# Altium schematic sheet USBgpib-v3-USBTMC.SchDoc
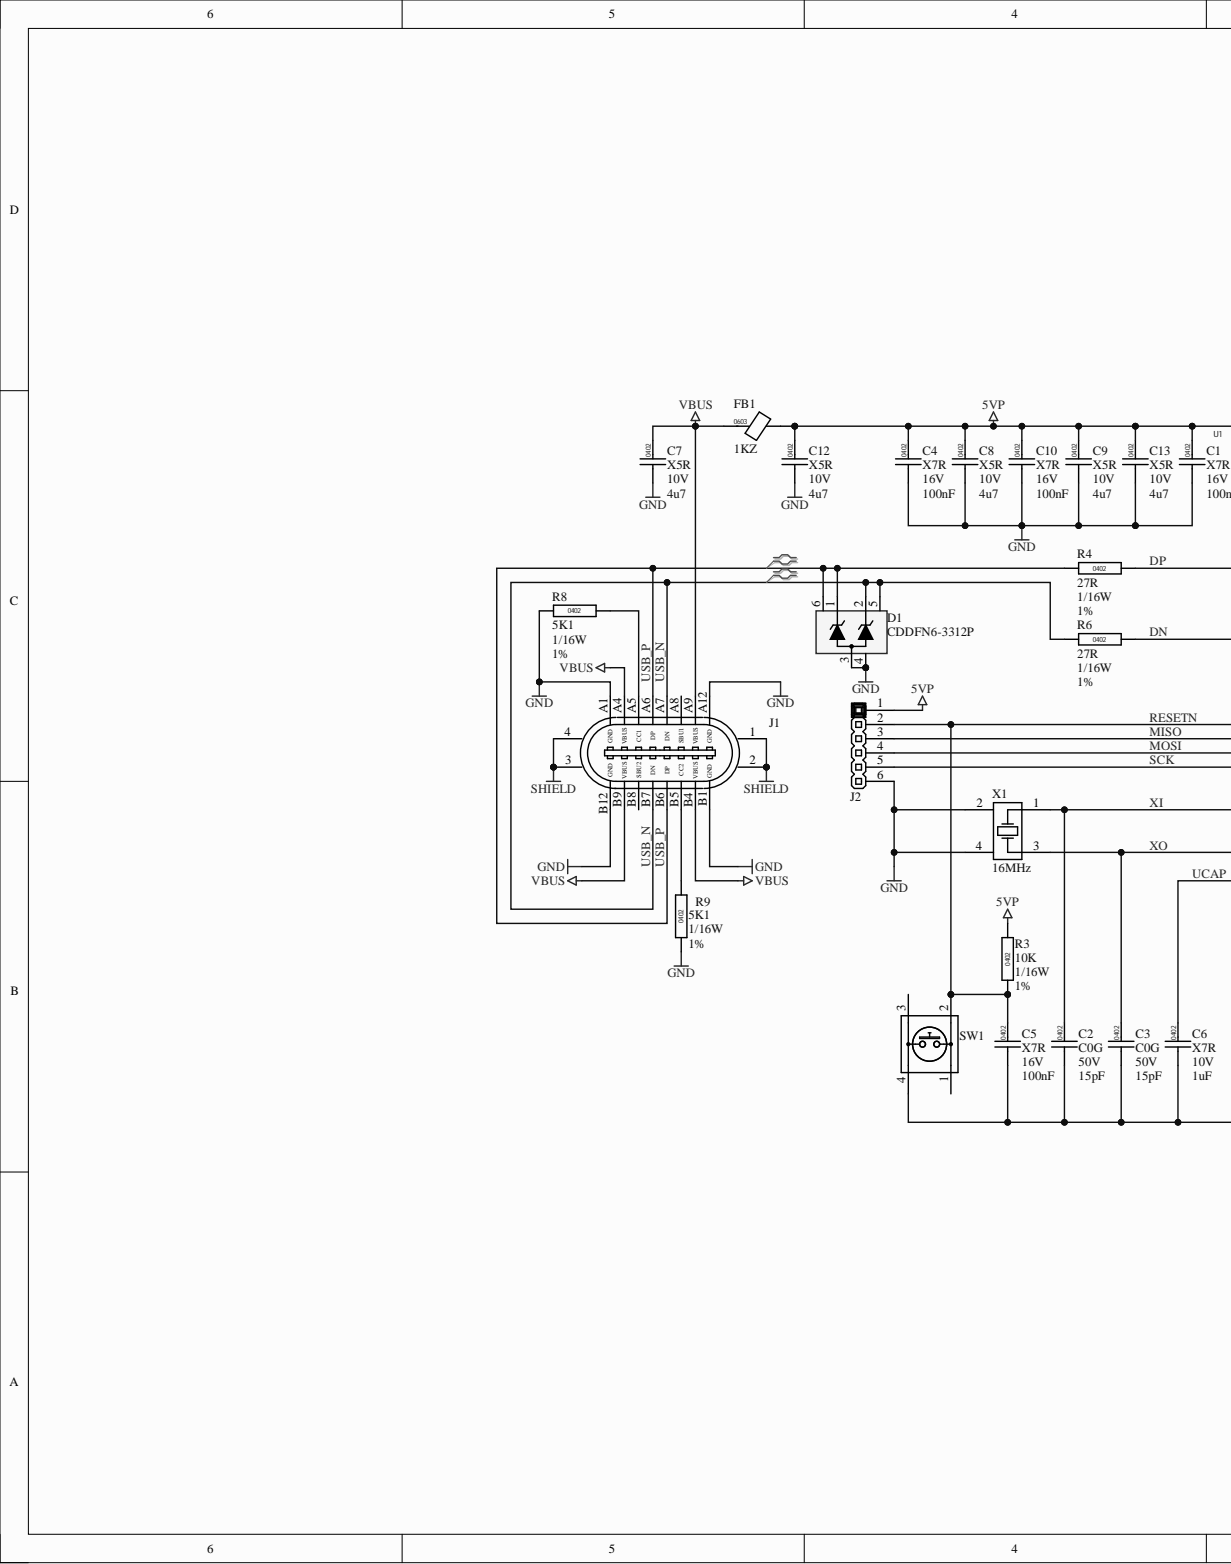
[diagram p0: USBgpib-v3-USBTMC.SchDoc, left]
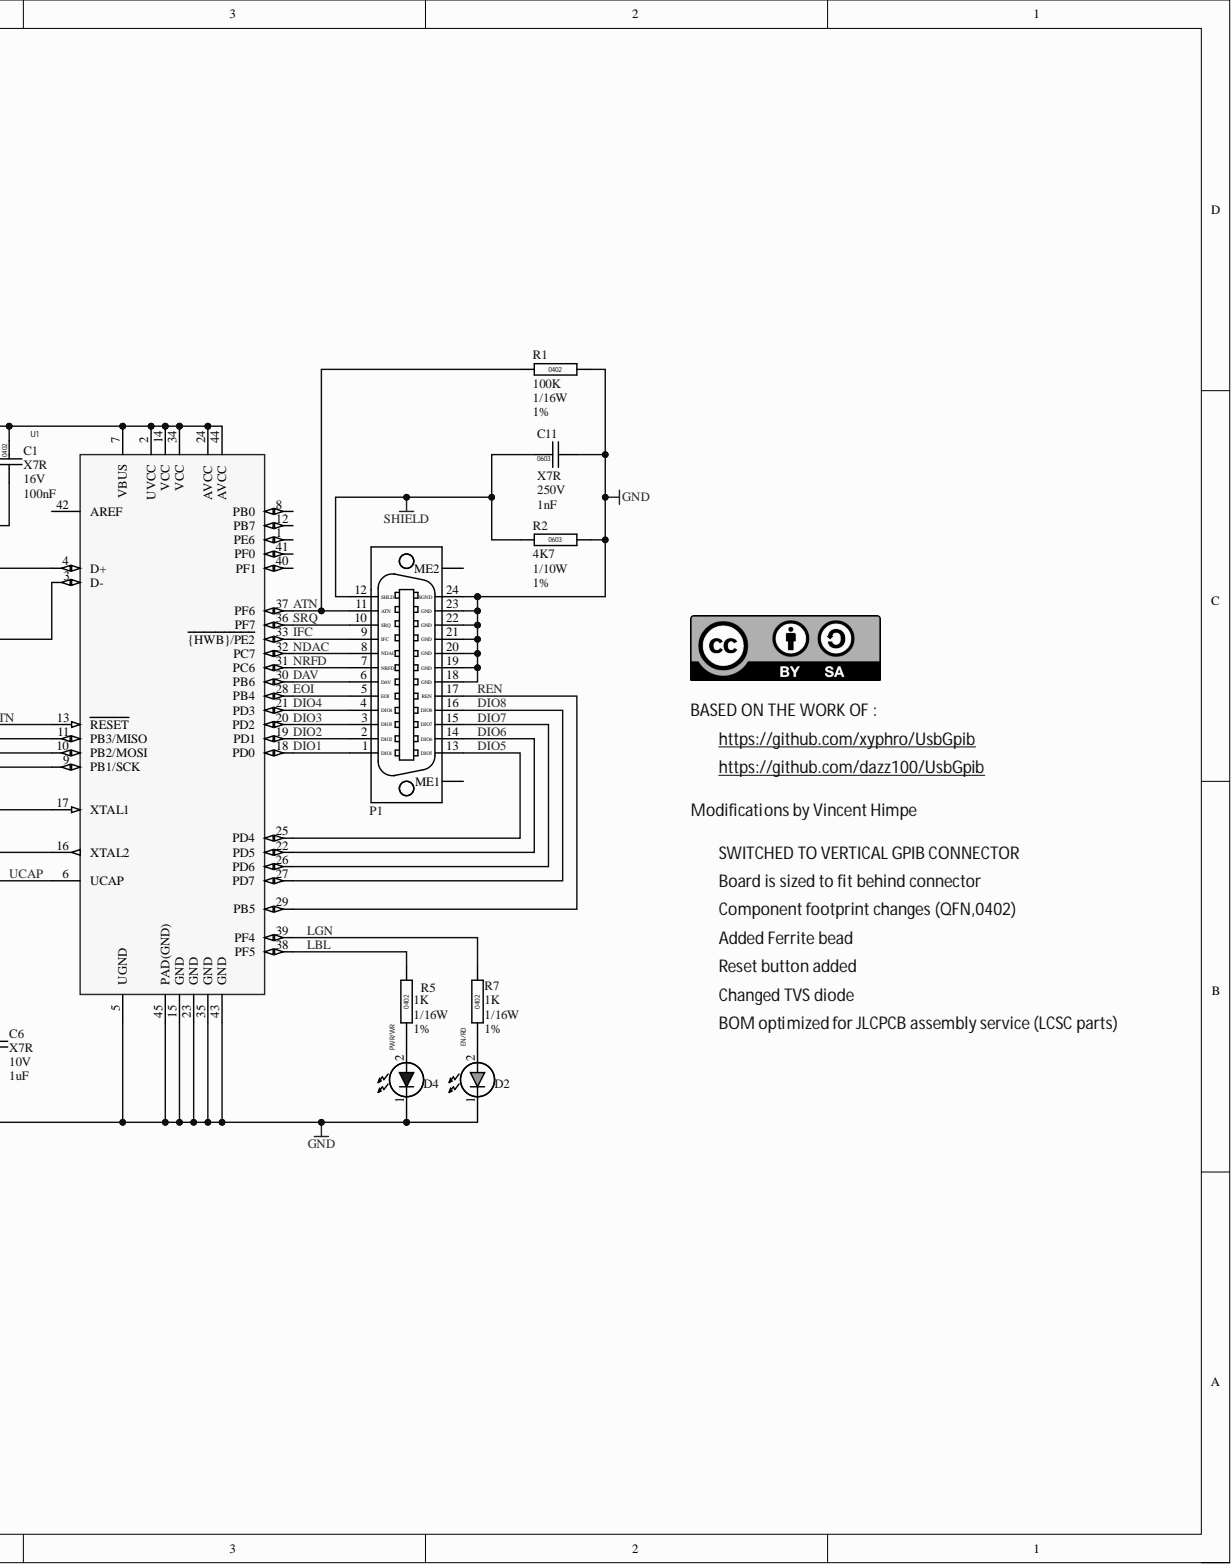
[diagram p1: USBgpib-v3-USBTMC.SchDoc, right]

## Parts
C1  Capacitance: 100nF  Dielectric: X7R  Package / Case: 0402  Voltage: 16V  [CAPC0402]  (hidden comment: CAP0402)
C2  Capacitance: 15pF  Dielectric: C0G  Package / Case: 0402  Voltage: 50V  [CAPC0402]  (hidden comment: CAP0603)
C3  Capacitance: 15pF  Dielectric: C0G  Package / Case: 0402  Voltage: 50V  [CAPC0402]  (hidden comment: CAP0603)
C4  Capacitance: 100nF  Dielectric: X7R  Package / Case: 0402  Voltage: 16V  [CAPC0402]  (hidden comment: CAP0402)
C5  Capacitance: 100nF  Dielectric: X7R  Package / Case: 0402  Voltage: 16V  [CAPC0402]  (hidden comment: CAP0402)
C6  Capacitance: 1uF  Dielectric: X7R  Voltage: 10V  [CAPC0402]  (hidden comment: CAP0402)
C7  Capacitance: 4u7  Dielectric: X5R  Package / Case: 0402  Voltage: 10V  [CAPC0402]  (hidden comment: CAP0402)
C8  Capacitance: 4u7  Dielectric: X5R  Package / Case: 0402  Voltage: 10V  [CAPC0402]  (hidden comment: CAP0402)
C9  Capacitance: 4u7  Dielectric: X5R  Package / Case: 0402  Voltage: 10V  [CAPC0402]  (hidden comment: CAP0402)
C10  Capacitance: 100nF  Dielectric: X7R  Package / Case: 0402  Voltage: 16V  [CAPC0402]  (hidden comment: CAP0402)
C11  Dielectric: X7R  Package / Case: 0603  Voltage: 250V  [CAPC0603]  (hidden comment: CAP0603)
C12  Capacitance: 4u7  Dielectric: X5R  Package / Case: 0402  Voltage: 10V  [CAPC0402]  (hidden comment: CAP0402)
C13  Capacitance: 4u7  Dielectric: X5R  Package / Case: 0402  Voltage: 10V  [CAPC0402]  (hidden comment: CAP0402)
D1  CDDFN6-3312P  [SON40P100X50-6L]
D2  LED-PLCC2-GREEN  [LED-PLCC2-GREEN]  (value not drawn)
D4  LED-PLCC2-BLUE  [LED-PLCC2-BLUE]  (value not drawn)
FB1  value 1KZ  [FB0603]  (hidden comment: FB0603)
J1  CONN-USBC-USB2-VERT  [CONN-CUI-UJ20-C-V-C-3-SMT-TR]  (value not drawn)
J2  CONN-HEADER-PIN-1X6  [HEADER-1X6]  (value not drawn)
P1  CONN-NORCOMP-111-024-113R00  [CONN-NORCOMP-111-024-113L001]  (value not drawn)
R1  value 100K  Power: 1/16W  [RESC0402]  (hidden comment: RESC0402)
R2  value 4K7  Power: 1/10W  Tolerance: 1%  [RESC0603]  (hidden comment: RESC0603)
R3  value 10K  Power: 1/16W  Tolerance: 1%  [RESC0402]  (hidden comment: RESC0402)
R4  value 27R  [RESC0402]  (hidden comment: RESC0402)
R5  value 1K  Power: 1/16W  Tolerance: 1%  [RESC0402]  (hidden comment: RESC0402)
R6  value 27R  Power: 1/16W  Tolerance: 1%  [RESC0402]  (hidden comment: RESC0402)
R7  value 1K  Power: 1/16W  Tolerance: 1%  [RESC0402]  (hidden comment: RESC0402)
R8  value 5K1  Power: 1/16W  Tolerance: 1%  [RESC0402]  (hidden comment: RESC0402)
R9  value 5K1  Power: 1/16W  Tolerance: 1%  [RESC0402]  (hidden comment: RESC0402)
SW1  SWITCH-PANASONIC-EVP-AA202K  [SWITCH-PANASONIC-EVP-AA202K]  (value not drawn)
U1  ATMEGA32U4-MUR  [Package_QFP:TQFP-44_10x10mm_P0.8mm]  (value not drawn)
X1  16MHz  [XOSC-YXC-2016]

## Nets
5VP: C1.1 C4.1 C8.1 C9.1 C10.1 C12.1 C13.1 FB1.2 J2.1 R3.1 U1.2(UVCC) U1.7(VBUS) U1.14(VCC) U1.24(AVCC) U1.34(VCC) U1.44(AVCC)
GND: C1.2 C2.2 C3.2 C4.2 C5.2 C6.2 C7.2 C8.2 C9.2 C10.2 C11.2 C12.2 C13.2 D1.3 D1.4 D2.1 D4.1 J1.A1(GND) J1.A12(GND) J1.B1(GND) J1.B12(GND) J2.6 P1.18 P1.19(GND) P1.20(GND) P1.21(GND) P1.22(GND) P1.23 P1.24(SGND) R1.2 R2.2 R8.2 R9.2 SW1.4 U1.5(UGND) U1.15(GND) U1.23(GND) U1.35(GND) U1.43(GND) U1.45(PAD(GND)) X1.2 X1.4
SHIELD: C11.1 J1.1 J1.2 J1.3 J1.4 P1.12(SHLD) R2.1
VBUS: C7.1 FB1.1 J1.A4(VBUS) J1.A9(VBUS) J1.B4(VBUS) J1.B9(VBUS)
ATN: P1.11 R1.1 U1.37(PF6)
DAV: P1.6 U1.30(PB6)
DIO1: P1.1 U1.18(PD0)
DIO2: P1.2(DIO2) U1.19(PD1)
DIO3: P1.3(DIO3) U1.20(PD2)
DIO4: P1.4(DIO4) U1.21(PD3)
DIO5: P1.13 U1.25(PD4)
DIO6: P1.14(DIO6) U1.22(PD5)
DIO7: P1.15(DIO7) U1.26(PD6)
DIO8: P1.16(DIO8) U1.27(PD7)
DN: R6.2 U1.3(D-)
DP: R4.2 U1.4(D+)
EOI: P1.5(EOI) U1.28(PB4)
IFC: P1.9(IFC) U1.33({HWB}/PE2)
LBL: R5.1 U1.38(PF5)
LGN: R7.1 U1.39(PF4)
MISO: J2.3 U1.11(PB3/MISO)
MOSI: J2.4 U1.10(PB2/MOSI)
NDAC: P1.8(NDAC) U1.32(PC7)
NRFD: P1.7(NRFD) U1.31(PC6)
REN: P1.17(REN) U1.29(PB5)
RESETN: C5.1 J2.2 R3.2 SW1.2 U1.13(RESET)
SCK: J2.5 U1.9(PB1/SCK)
SRQ: P1.10(SRQ) U1.36(PF7)
UCAP: C6.1 U1.6(UCAP)
USB_N: D1.2 D1.5 J1.A7(DN) J1.B7(DN) R6.1
USB_P: D1.1 D1.6 J1.A6(DP) J1.B6(DP) R4.1
XI: C2.1 U1.17(XTAL1) X1.1
XO: C3.1 U1.16(XTAL2) X1.3
NetD2_2: D2.2 R7.2
NetD4_2: D4.2 R5.2
NetJ1_A5: J1.A5(CC1) R8.1
NetJ1_B5: J1.B5(CC2) R9.1

Not connected: J1 A8(SBU1) B8(SBU2); P1 ME1 ME2; SW1 1 3; U1 1(PE6) 8(PB0) 12(PB7) 40(PF1) 41(PF0) 42(AREF)

## Library data of the parts
C1 C4 C5 C10 = CAPC-0402-100nF-16V-X7R: CAPACITOR,CERAMIC,100nF,16V,X7R,0402; Component Kind: Standard; LCSC: C60474; Library Name: CAPACITORS.SchLib; Supplier 1: Digikey; Supplier Part Number 1: 1292-1630-1-ND
C2 C3 = CAPC-0402-15PF-50V-C0G: CAPACITOR,CERAMIC,15pF,50V,C0G,0402; Component Kind: Standard; LCSC: C3906764; Library Name: CAPACITORS.SchLib; Supplier 1: Digikey; Supplier Part Number 1: 311-3780-1-ND
C6 = CAPC-0402-1u-10V-X7R: CAPACITOR,CERAMIC,1uF,10V,X7R,0402; Component Kind: Standard; LCSC: C528974; Library Name: CAPACITORS.SchLib; Package / Case: 0603; Supplier 1: Mouser; Supplier Part Number 1: 81-GRM155Z71A105KE1D
C7-C9 C12 C13 = CAPC-0402-4u7-10V-X5R: CAPACITOR,CERAMIC,4u7,10V,X5R,0402; Component Kind: Standard; LCSC: C23733; Library Name: CAPACITORS.SchLib; Supplier 1: Digikey; Supplier Part Number 1: 1276-1482-1-ND
C11 = CAPC-0603-1nF-250V-X7R: CAPACITOR,CERAMIC,1nF,250V,X7R,0603; Capacitance: 1nF; Component Kind: Standard; LCSC: C277510; Library Name: CAPACITORS.SchLib; Supplier 1: Digikey; Supplier Part Number 1: 311-4104-1-ND
D1 = TVS-BOURNS-CDDFN6-3312P: TVS,USB,BOURNS,CDDFN6-3312P; Component Kind: Standard; LCSC: C3700524; Library Name: DIODES.SchLib
D2 = LED-PLCC2-GREEN: LED,GREEN,PLCC2; Component Kind: Standard; LCSC: C5248446; Library Name: LED.SchLib; Supplier 1: Digikey; Supplier Part Number 1: 475-LGT67K-H2K1-24-ZCT-ND
D4 = LED-PLCC2-BLUE: LED,BLUE,PLCC2; Component Kind: Standard; LCSC: C6323026; Library Name: LED.SchLib; Supplier 1: Mouser; Supplier Part Number 1: 78-VLMB41P1Q2-GS18
FB1 = FB0603-1KZ-0R2: FERRITE,1KZ@100MHz,0R2DC,0603; Component Kind: Standard; LCSC: C160982; Supplier 1: Arrow Electronics; Supplier Part Number 1: BLM18KG102SN1D; Library Name: FERRITES.SCHLIB
J1 = CONN-USBC-USB2-VERT: CONN,USB-C,VERTICAL,TH; Component Kind: Standard; Library Name: CONNECTORS.SchLib
J2 = CONN-HEADER-PIN-1X6: CONN,PINHEADER,1X6,2.45MM,VERT,GOLD; Component Kind: Standard; Library Name: CONNECTORS.SchLib
P1 = CONN-NORCOMP-111-024-113R00: CONN,GPIB,24P,VERT,TH; Component Kind: Standard; Library Name: CONNECTORS.SchLib; Supplier 1: Digikey; Supplier Part Number 1: 1024RMA-ND
R1 = RESC-0402-100K-1%-1/10W: RESISTOR,100K,1%,63mW,0402 (1005); Component Kind: Standard; LCSC: C60491; Library Name: RESISTORS.SchLib; Supplier 1: Future Electronics; Supplier Part Number 1: 3068008; Tolerance: 1%
R2 = RESC-0603-4K7-1%-1/10W: RESISTOR,4K7,1%,100mW,0603 (1608); Component Kind: Standard; LCSC: C99782; Library Name: RESISTORS.SchLib; Supplier 1: Future Electronics; Supplier 2: Digikey; Supplier 3: Digikey; Supplier 4: Avnet; Supplier Part Number 1: 5489695; Supplier Part Number 2: P4.70KHCT-ND; Supplier Part Number 3: RMCF0603FT4K70CT-ND; Supplier Part Number 4: 1622960-1
R3 = RESC-0402-10K-1%-1/10W: RESISTOR,10K,1%,63mW,0402 (1005); Component Kind: Standard; LCSC: C60490; Library Name: RESISTORS.SchLib; Supplier 1: Future Electronics; Supplier Part Number 1: 3388022
R4 = RESC-0402-27R-1%-1/10W: RESISTOR,27R,1%,63mW,0402 (1005); Power: 1/16W; Component Kind: Standard; LCSC: C138021; Library Name: RESISTORS.SchLib; Supplier 1: Future Electronics; Supplier Part Number 1: 2577120; Tolerance: 1%
R5 R7 = RESC-0402-1K-1%-1/10W: RESISTOR,1K,1%,63mW,0402 (1005); Component Kind: Standard; LCSC: C106235; Library Name: RESISTORS.SchLib; Supplier 1: Future Electronics; Supplier Part Number 1: 7825607
R6 = RESC-0402-27R-1%-1/10W: RESISTOR,27R,1%,63mW,0402 (1005); Component Kind: Standard; LCSC: C138021; Library Name: RESISTORS.SchLib; Supplier 1: Future Electronics; Supplier Part Number 1: 2577120
R8 R9 = RESC-0402-5K1-1%-1/10W: RESISTOR,5K1,1%,63mW,0402 (1005); Component Kind: Standard; LCSC: C105872; Library Name: RESISTORS.SchLib; Supplier 1: Future Electronics; Supplier Part Number 1: 6053649
SW1 = SWITCH-PANASONIC-EVP-AA202K: SW,SMD,TACT,4PIN,2.9X3.5,4PIN; Component Kind: Standard; LCSC: C483888; Library Name: SWITCH.SchLib; Supplier 1: Mouser; Supplier Part Number 1: 667-EVP-AA202K
U1 = IC-ATMEL-ATMEGA32U4-QFN: IC,CPU,ATMEG32U4-MU,QFN44; Component Kind: Standard; LCSC: C45874; Library Name: IC-CPU.SchLib
X1 = XTAL-YXC-XL7EL89COI-111YLC-16M: XTAL,16MHz,2016,4P; Component Kind: Standard; Frequency: 16MHz; LCSC: C2965579; Library Name: XTAL.SchLib
